# Altium schematic sheet Sheet3.SchDoc(Sheet3)
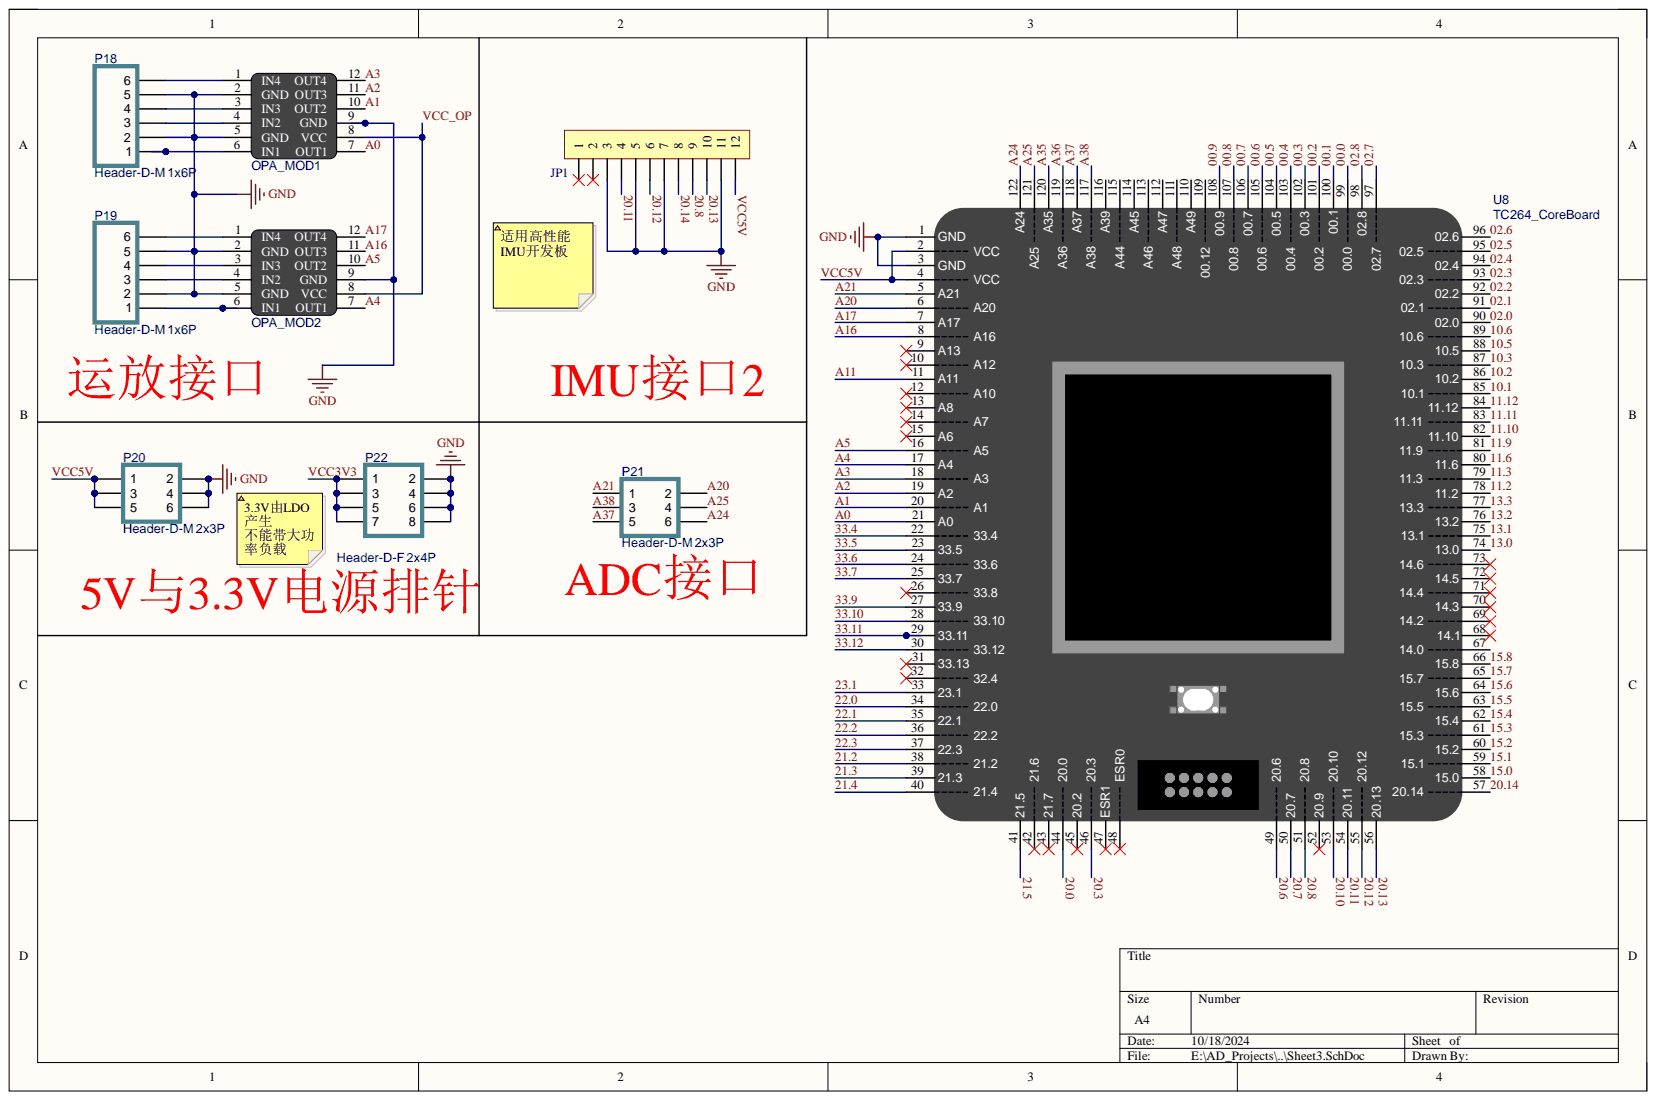
[diagram p0: Sheet3.SchDoc]

## Parts
JP1  CON-12P  [FPC1.0-WT-12P]  (value not drawn)
OPA_MOD1  OPA_MOD  [SEEKFREE_4CHANEL_OPAMOD]
OPA_MOD2  OPA_MOD  [SEEKFREE_4CHANEL_OPAMOD]
P18  Header-D-M 1x6P  [HDR1X6-M]
P19  Header-D-M 1x6P  [HDR1X6-M]
P20  Header-D-M 2x3P  [HDR2X3-M]
P21  Header-D-M 2x3P  [HDR2X3-M]
P22  Header-D-F 2x4P  [HDR2X4-F]
U8  TC264_CoreBoard  [TC264_CoreBoard]

## Nets
GND: JP1.3 JP1.5 JP1.7 JP1.11 OPA_MOD1.2(GND) OPA_MOD1.5(GND) OPA_MOD1.9(GND) OPA_MOD2.2(GND) OPA_MOD2.5(GND) OPA_MOD2.9(GND) P18.2 P18.5 P19.2 P19.5 P20.2 P20.4 P20.6 P22.2 P22.4 P22.6 P22.8 U8.1(GND) U8.3(GND)
00.0: U8.99
00.1: U8.100(00.1)
00.2: U8.101
00.3: U8.102(00.3)
00.4: U8.103
00.5: U8.104(00.5)
00.6: U8.105
00.7: U8.106(00.7)
00.8: U8.107
00.9: U8.108(00.9)
02.0: U8.90(02.0)
02.1: U8.91
02.2: U8.92(02.2)
02.3: U8.93
02.4: U8.94(02.4)
02.5: U8.95
02.6: U8.96(02.6)
02.7: U8.97
02.8: U8.98(02.8)
10.1: U8.85
10.2: U8.86(10.2)
10.3: U8.87
10.5: U8.88(10.5)
10.6: U8.89
11.2: U8.78(11.2)
11.3: U8.79
11.6: U8.80(11.6)
11.9: U8.81
11.10: U8.82(11.10)
11.11: U8.83
11.12: U8.84(11.12)
13.0: U8.74(13.0)
13.1: U8.75
13.2: U8.76(13.2)
13.3: U8.77
15.0: U8.58(15.0)
15.1: U8.59
15.2: U8.60(15.2)
15.3: U8.61
15.4: U8.62(15.4)
15.5: U8.63
15.6: U8.64(15.6)
15.7: U8.65
15.8: U8.66(15.8)
20.0: U8.44
20.3: U8.46
20.6: U8.49
20.7: U8.50(20.7)
20.8: JP1.9 U8.51
20.10: U8.53
20.11: JP1.4 U8.54(20.11)
20.12: JP1.6 U8.55
20.13: JP1.10 U8.56(20.13)
20.14: JP1.8 U8.57
21.2: U8.38
21.3: U8.39(21.3)
21.4: U8.40
21.5: U8.41(21.5)
22.0: U8.34
22.1: U8.35(22.1)
22.2: U8.36
22.3: U8.37(22.3)
23.1: U8.33(23.1)
33.4: U8.22
33.5: U8.23(33.5)
33.6: U8.24
33.7: U8.25(33.7)
33.9: U8.27(33.9)
33.10: U8.28
33.11: U8.29(33.11)
33.12: U8.30
A0: OPA_MOD1.7(OUT1) U8.21(A0)
A1: OPA_MOD1.10(OUT2) U8.20
A2: OPA_MOD1.11(OUT3) U8.19(A2)
A3: OPA_MOD1.12(OUT4) U8.18
A4: OPA_MOD2.7(OUT1) U8.17(A4)
A5: OPA_MOD2.10(OUT2) U8.16
A11: U8.11(A11)
A16: OPA_MOD2.11(OUT3) U8.8
A17: OPA_MOD2.12(OUT4) U8.7(A17)
A20: P21.2 U8.6
A21: P21.1 U8.5(A21)
A24: P21.6 U8.122(A24)
A25: P21.4 U8.121
A35: U8.120(A35)
A36: U8.119
A37: P21.5 U8.118(A37)
A38: P21.3 U8.117
VCC3V3: P22.1 P22.3 P22.5 P22.7
VCC5V: JP1.12 P20.1 P20.3 P20.5 U8.2 U8.4
VCC_OP: OPA_MOD1.8(VCC) OPA_MOD2.8(VCC)
NetOPA_MOD1_1: OPA_MOD1.1(IN4) P18.6
NetOPA_MOD1_3: OPA_MOD1.3(IN3) P18.4
NetOPA_MOD1_4: OPA_MOD1.4(IN2) P18.3
NetOPA_MOD1_6: OPA_MOD1.6(IN1) P18.1
NetOPA_MOD2_1: OPA_MOD2.1(IN4) P19.6
NetOPA_MOD2_3: OPA_MOD2.3(IN3) P19.4
NetOPA_MOD2_4: OPA_MOD2.4(IN2) P19.3
NetOPA_MOD2_6: OPA_MOD2.6(IN1) P19.1

Not connected: JP1 1 2; U8 9(A13) 10 12 13(A8) 14 15(A6) 26 31(33.13) 32 42 43(21.7) 45(20.2) 47(ESR1) 48 52(20.9) 67 68(14.1) 69 70(14.3) 71 72(14.5) 73 109 110(A49) 111 112(A47) 113 114(A45) 115 116(A39)

## Library data of the parts
JP1 = Header 12: 12P连接器; 封装库来自淘宝卖家：黄晶宝石: 获取最新版本https://shop463601444.taobao.com; BOM名称: 12P连接器; Component Kind: Standard; Library Name: 5.0 - 1.00mm连接器(FPC1.0_SH1.0_1.0排针排母).SchLib
OPA_MOD1 OPA_MOD2 = OPA_4CHANNEL_SEEKFREE: 逐飞科技 运放模块; Component Kind: Standard; Library Name: TC264_主板_V2.6.SCHLIB
P18 P19 = Header-D-M 1x6P: 1x6pin, 直插, 排针; Component Kind: Standard; Library Name: TC264_主板_V2.6.SCHLIB; Revision: V1.0; Value: Header-D-M 1x6P
P20 P21 = Header-D-M 2x3P: 2x3pin, 直插, 双排针; Component Kind: Standard; Library Name: TC264_主板_V2.6.SCHLIB; Revision: V1.0; Value: Header-D-M 2x3P
P22 = Header-D-F 2x4P: 2x4pin, 直插, 双排座; Component Kind: Standard; Library Name: TC264_主板_V2.6.SCHLIB; Revision: V1.0; Value: Header-D-F 2x4P
U8 = TC264_CoreBoard: 逐飞科技TC264核心板; Component Kind: Standard; Library Name: TC264_主板_V2.6.SCHLIB
